# Altium schematic sheet Sheet1.SchDoc
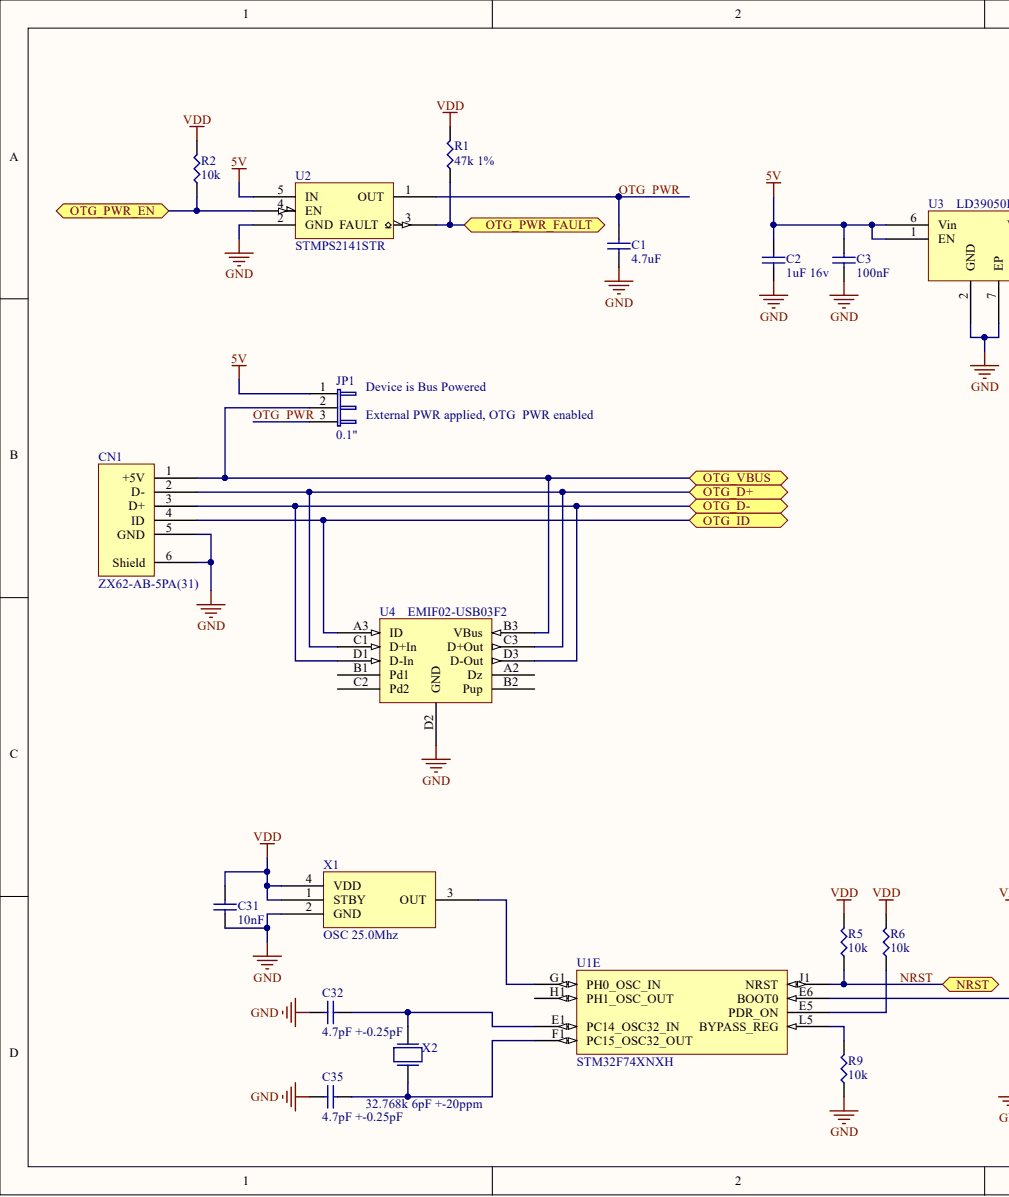
[diagram p0: Sheet1.SchDoc, left]
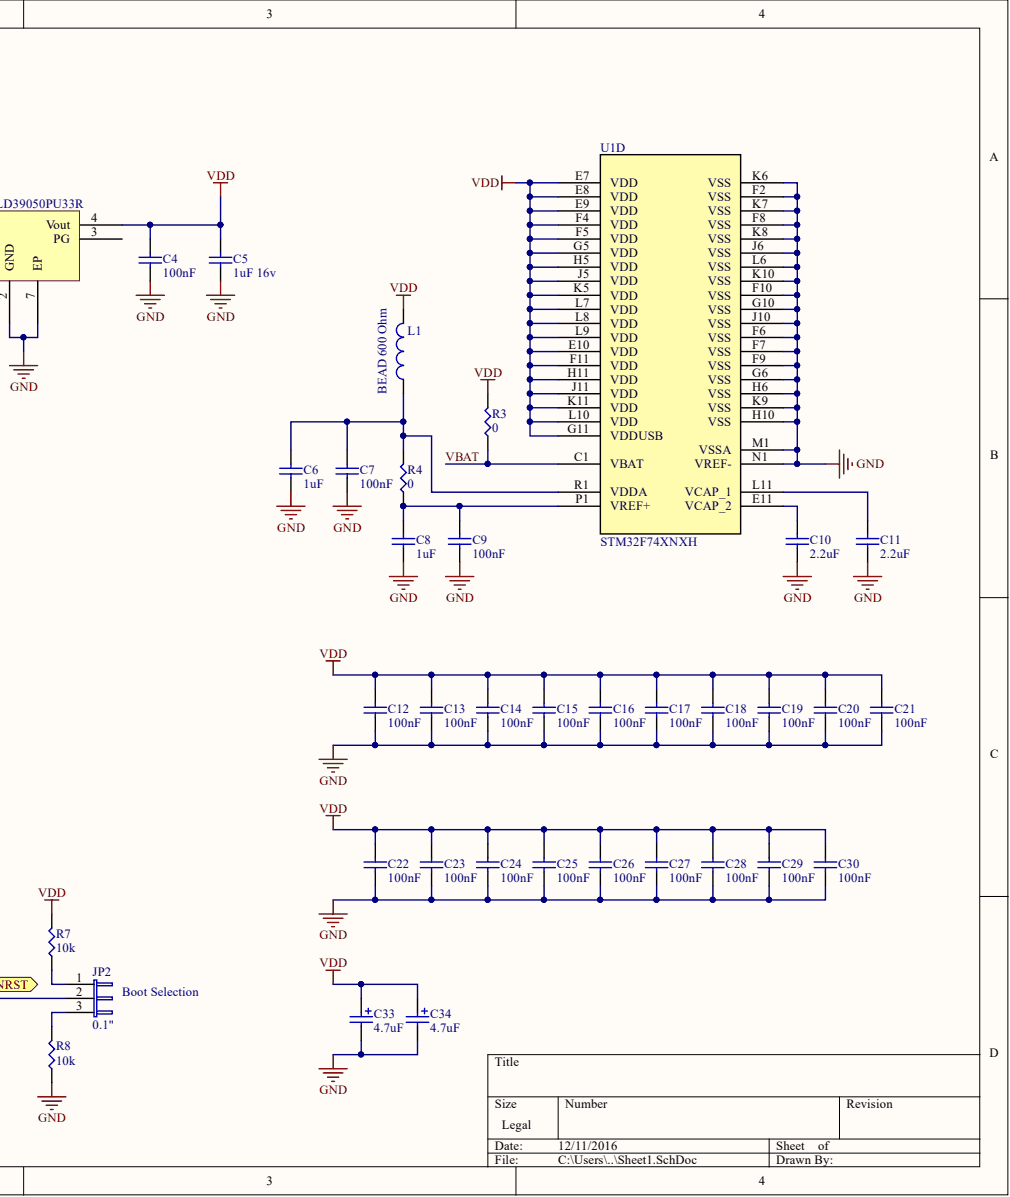
[diagram p1: Sheet1.SchDoc, right]

## Parts
C1  4.7uF  [C0603]
C2  1uF 16v
C3  100nF  [C0402]
C4  100nF  [C0402]
C5  1uF 16v
C6  1uF  [C0402]
C7  100nF  [C0402]
C8  1uF  [C0402]
C9  100nF  [C0402]
C10  2.2uF  [C0402]
C11  2.2uF  [C0402]
C12  100nF  [C0402]
C13  100nF  [C0402]
C14  100nF  [C0402]
C15  100nF  [C0402]
C16  100nF  [C0402]
C17  100nF  [C0402]
C18  100nF  [C0402]
C19  100nF  [C0402]
C20  100nF  [C0402]
C21  100nF  [C0402]
C22  100nF  [C0402]
C23  100nF  [C0402]
C24  100nF  [C0402]
C25  100nF  [C0402]
C26  100nF  [C0402]
C27  100nF  [C0402]
C28  100nF  [C0402]
C29  100nF  [C0402]
C30  100nF  [C0402]
C31  10nF  [C0402]
C32  4.7pF +-0.25pF  Capacitance: 4.7pF  [C0402]
C33  4.7uF  [C0805 Polarized]
C34  4.7uF  [C0805 Polarized]
C35  4.7pF +-0.25pF  Capacitance: 4.7pF  [C0402]
CN1  ZX62-AB-5PA(31)  [ZX62-AB-5PA(31)]
JP1  0.1"  [Jumper 3pin 0.1"]
JP2  0.1"  [Jumper 3pin 0.1"]
L1  BEAD 600 Ohm  [L0603]
R1  47k 1%  Resistance (Ohms): 47k  [R0402]
R2  10k  [R0402]
R3  0  [R0805]
R4  0  [R0805]
R5  10k  [R0402]
R6  10k  [R0402]
R7  10k  [R0402]
R8  10k  [R0402]
R9  10k  [R0402]
U1  STM32F74XNXH  [TFBGA-216_13X13]  (parts D,E)
U2  STMPS2141STR  [SOT95P280X145-5N]
U3  LD39050PU33R  [LD39050]
U4  EMIF02-USB03F2  [EMIF02-USB03F2]
X1  OSC 25.0Mhz  [OSC_3.2x2.5]
X2  32.768k 6pF +-20ppm  Load Capacitance: 6pF  [CRYSTAL_3.20x1.50]

## Ports
NRST, OTG_D+, OTG_D-, OTG_ID, OTG_PWR_EN, OTG_PWR_FAULT, OTG_VBUS

## Nets
5V: C2.2 C3.2 JP1.1 U2.5(IN) U3.1(EN) U3.6(Vin)
GND: C1.1 C2.1 C3.1 C4.1 C5.1 C6.1 C7.1 C8.1 C9.1 C10.1 C11.1 C12.1 C13.1 C14.1 C15.1 C16.1 C17.1 C18.1 C19.1 C20.1 C21.1 C22.1 C23.1 C24.1 C25.1 C26.1 C27.1 C28.1 C29.1 C30.1 C31.1 C32.1 C33.2 C34.2 C35.1 CN1.5(GND) CN1.6(Shield) R8.1 R9.1 U1.F2(VSS) U1.F6(VSS) U1.F7(VSS) U1.F8(VSS) U1.F9(VSS) U1.F10(VSS) U1.G6(VSS) U1.G10(VSS) U1.H6(VSS) U1.H10(VSS) U1.J6(VSS) U1.J10(VSS) U1.K6(VSS) U1.K7(VSS) U1.K8(VSS) U1.K9(VSS) U1.K10(VSS) U1.L6(VSS) U1.M1(VSSA) U1.N1(VREF-) U2.2(GND) U3.2(GND) U3.7(EP) U4.D2(GND) X1.2(GND)
VDD: C4.2 C5.2 C12.2 C13.2 C14.2 C15.2 C16.2 C17.2 C18.2 C19.2 C20.2 C21.2 C22.2 C23.2 C24.2 C25.2 C26.2 C27.2 C28.2 C29.2 C30.2 C31.2 C33.1 C34.1 L1.2 R1.2 R2.2 R3.2 R5.2 R6.2 R7.2 U1.E7(VDD) U1.E8(VDD) U1.E9(VDD) U1.E10(VDD) U1.F4(VDD) U1.F5(VDD) U1.F11(VDD) U1.G5(VDD) U1.G11(VDDUSB) U1.H5(VDD) U1.H11(VDD) U1.J5(VDD) U1.J11(VDD) U1.K5(VDD) U1.K11(VDD) U1.L7(VDD) U1.L8(VDD) U1.L9(VDD) U1.L10(VDD) U3.4(Vout) X1.1(STBY) X1.4(VDD)
NRST: R5.1 U1.J1(NRST) ; port NRST
OTG_ID: CN1.4(ID) U4.A3(ID) ; port OTG_ID
OTG_PWR: C1.2 JP1.3 U2.1(OUT)
OTG_PWR_EN: R2.1 U2.4(EN) ; port OTG_PWR_EN
OTG_PWR_FAULT: R1.1 U2.3(FAULT) ; port OTG_PWR_FAULT
OTG_VBUS: CN1.1(+5V) JP1.2 U4.B3(VBus) ; port OTG_VBUS
VBAT: R3.1 U1.C1(VBAT)
NetC6_2: C6.2 C7.2 L1.1 R4.2 U1.R1(VDDA)
NetC8_2: C8.2 C9.2 R4.1 U1.P1(VREF+)
NetC10_2: C10.2 U1.E11(VCAP_2)
NetC11_2: C11.2 U1.L11(VCAP_1)
NetC32_2: C32.2 U1.E1(PC14_OSC32_IN) X2.1
NetC35_2: C35.2 U1.F1(PC15_OSC32_OUT) X2.2
NetCN1_2: CN1.2(D-) U4.C1(D+In) U4.C3(D+Out)
NetCN1_3: CN1.3(D+) U4.D1(D-In) U4.D3(D-Out)
NetJP2_1: JP2.1 R7.1
NetJP2_2: JP2.2 U1.E6(BOOT0)
NetJP2_3: JP2.3 R8.2
NetR6_1: R6.1 U1.E5(PDR_ON)
NetR9_2: R9.2 U1.L5(BYPASS_REG)
NetU1_G1: U1.G1(PH0_OSC_IN) X1.3(OUT)

Not connected: U1 H1(PH1_OSC_OUT); U3 3(PG); U4 A2(Dz) B1(Pd1) B2(Pup) C2(Pd2)

## Library data of the parts
C1 = CL10A475MP5LNNC: CAP CER 4.7UF 10V X5R 0603; Manufacturer: Samsung Electro-Mechanics America, Inc.; Capacitance: 4.7µF; Tolerance: ±20%; Applications: General Purpose; Category: Capacitors; Component Kind: Standard; ComponentLink1Description: http://www.samsungsem.com/kr/support/library/product-catalog/__icsFiles/afieldfile/2016/09/27/MLCC.pdf; ComponentLink1URL: http://www.samsungsem.com/kr/support/library/product-catalog/__icsFiles/afieldfile/2016/09/27/MLCC.pdf; Features: Low Profile; Height - Seated (Max): -; Lead Spacing: -; Lead Style: -; Library Name: Main.SchLib; Manufacturer Part Number: CL10A475MP5LNNC; Mounting Type: Surface Mount, MLCC; Operating Temperature: -55°C ~ 85°C; Package / Case: 0603 (1608 Metric); Packaging: Cut Tape (CT); Part Status: Active; Pricing 1: 1=0.1, 10=0.074, 25=0.0544, 50=0.0422, 100=0.0349, 250=0.02892, 500=0.0245, 1000=0.02028 (USD); Ratings: -; RoHS: RoHS Compliant; Size / Dimension: 0.063" L x 0.031" W (1.60mm x 0.80mm); Stock 1: 31898; Supplier 1: Digi-Key; Supplier Part Number 1: 1276-6684-1-ND; Temperature Coefficient: X5R; Thickness (Max): 0.022" (0.55mm); Voltage - Rated: 10V
C2 C5 = CC0603ZRY5V7BB105: CAP CER 1UF 16V Y5V 0603; Manufacturer: Yageo; Capacitance: 1µF; Temperature Coefficient: Y5V (F); Tolerance: -20%, +80%; Applications: General Purpose; Category: Capacitors; Component Kind: Standard; ComponentLink1Description: http://www.yageo.com/documents/recent/UPY-GPHC_Y5V_6.3V-to-50V_6.pdf; ComponentLink1URL: http://www.yageo.com/documents/recent/UPY-GPHC_Y5V_6.3V-to-50V_6.pdf; Failure Rate: -; Features: -; Height - Seated (Max): -; Lead Spacing: -; Lead Style: -; Library Name: Main.SchLib; Manufacturer Part Number: CC0603ZRY5V7BB105; Mounting Type: Surface Mount, MLCC; Operating Temperature: -30°C ~ 85°C; Package / Case: 0603 (1608 Metric); Packaging: Cut Tape (CT); Part Status: Active; Pricing 1: 1=0.1, 10=0.033, 25=0.0236, 100=0.0152, 250=0.01248, 500=0.01068, 1000=0.00832 (USD); Ratings: -; RoHS: RoHS Compliant; Size / Dimension: 0.063" L x 0.031" W (1.60mm x 0.80mm); Stock 1: 840235; Supplier 1: Digi-Key; Supplier Part Number 1: 311-1372-1-ND; Thickness (Max): 0.035" (0.90mm); Voltage - Rated: 16V
C3 C4 C7 C9 C12-C30 = GRM155R71C104KA88J: CAP CER 0.1UF 16V X7R 0402; Manufacturer: Murata Electronics North America; Capacitance: 0.10µF; Tolerance: ±10%; Applications: General Purpose; Category: Capacitors; Component Kind: Standard; ComponentLink1Description: http://www.murata.com/~/media/webrenewal/support/library/catalog/products/capacitor/mlcc/c02e.pdf; ComponentLink1URL: http://www.murata.com/~/media/webrenewal/support/library/catalog/products/capacitor/mlcc/c02e.pdf; Features: -; Height - Seated (Max): -; Lead Spacing: -; Library Name: Main.SchLib; Manufacturer Part Number: GRM155R71C104KA88J; Mounting Type: Surface Mount, MLCC; Operating Temperature: -55°C ~ 125°C; Package / Case: 0402 (1005 Metric); Packaging: Cut Tape (CT); Part Status: Active; Pricing 1: 1=0.1, 10=0.012, 50=0.0066, 100=0.0055, 250=0.00452, 500=0.00386, 1000=0.003, 5000=0.0025, 10000=0.0023 (USD); Ratings: -; RoHS: RoHS Compliant; Size / Dimension: 0.039" L x 0.020" W (1.00mm x 0.50mm); Stock 1: 28696221; Supplier 1: Digi-Key; Supplier Part Number 1: 490-6328-1-ND; Temperature Coefficient: X7R; Thickness (Max): 0.022" (0.55mm); Voltage - Rated: 16V
C6 C8 = GRM155R60J105KE19D: CAP CER 1UF 6.3V X5R 0402; Manufacturer: Murata Electronics North America; Capacitance: 1µF; Tolerance: ±10%; Applications: General Purpose; Category: Capacitors; Component Kind: Standard; ComponentLink1Description: http://www.murata.com/~/media/webrenewal/support/library/catalog/products/capacitor/mlcc/c02e.pdf; ComponentLink1URL: http://www.murata.com/~/media/webrenewal/support/library/catalog/products/capacitor/mlcc/c02e.pdf; Features: -; Height - Seated (Max): -; Lead Spacing: -; Lead Style: -; Library Name: Main.SchLib; Manufacturer Part Number: GRM155R60J105KE19D; Mounting Type: Surface Mount, MLCC; Operating Temperature: -55°C ~ 85°C; Package / Case: 0402 (1005 Metric); Packaging: Cut Tape (CT); Part Status: Active; Pricing 1: 1=0.1, 10=0.021, 50=0.0114, 100=0.0096, 250=0.00784, 500=0.0067, 1000=0.00522, 5000=0.00435 (USD); Ratings: -; RoHS: RoHS Compliant; Size / Dimension: 0.039" L x 0.020" W (1.00mm x 0.50mm); Stock 1: 3970482; Supplier 1: Digi-Key; Supplier Part Number 1: 490-1320-1-ND; Temperature Coefficient: X5R; Thickness (Max): 0.022" (0.55mm); Voltage - Rated: 6.3V
C10 C11 = 04026D225KAT2A: CAP CER 2.2UF 6.3V X5R 0402; Manufacturer: AVX Corporation; Capacitance: 2.2µF; Tolerance: ±10%; Applications: General Purpose; Category: Capacitors; Component Kind: Standard; ComponentLink1Description: http://datasheets.avx.com/cx5r.pdf; ComponentLink1URL: http://datasheets.avx.com/cx5r.pdf; Features: -; Height - Seated (Max): -; Lead Spacing: -; Lead Style: -; Library Name: Main.SchLib; Manufacturer Part Number: 04026D225KAT2A; Mounting Type: Surface Mount, MLCC; Operating Temperature: -55°C ~ 85°C; Package / Case: 0402 (1005 Metric); Packaging: Cut Tape (CT); Part Status: Active; Pricing 1: 1=0.1, 10=0.075, 25=0.0544, 50=0.0424, 100=0.0351, 250=0.029, 500=0.02458, 1000=0.02035, 5000=0.01733 (USD); Ratings: -; RoHS: RoHS Compliant; Size / Dimension: 0.039" L x 0.020" W (1.00mm x 0.50mm); Stock 1: 639807; Supplier 1: Digi-Key; Supplier Part Number 1: 478-7885-1-ND; Temperature Coefficient: X5R; Thickness (Max): 0.022" (0.56mm); Voltage - Rated: 6.3V
C31 = GRM155R71H103KA88D: CAP CER 10000PF 50V X7R 0402; Manufacturer: Murata Electronics North America; Tolerance: ±10%; Applications: General Purpose; Capacitance: 10000pF; Category: Capacitors; Component Kind: Standard; ComponentLink1Description: http://www.murata.com/~/media/webrenewal/support/library/catalog/products/capacitor/mlcc/c02e.pdf; ComponentLink1URL: http://www.murata.com/~/media/webrenewal/support/library/catalog/products/capacitor/mlcc/c02e.pdf; Features: -; Height - Seated (Max): -; Lead Spacing: -; Lead Style: -; Library Name: Main.SchLib; Manufacturer Part Number: GRM155R71H103KA88D; Mounting Type: Surface Mount, MLCC; Operating Temperature: -55°C ~ 125°C; Package / Case: 0402 (1005 Metric); Packaging: Cut Tape (CT); Part Status: Active; Pricing 1: 1=0.1, 50=0.0052, 100=0.0044, 250=0.0036, 500=0.00308, 1000=0.0024, 5000=0.002 (USD); Ratings: -; RoHS: RoHS Compliant; Size / Dimension: 0.039" L x 0.020" W (1.00mm x 0.50mm); Stock 1: 4828857; Supplier 1: Digi-Key; Supplier Part Number 1: 490-4516-1-ND; Temperature Coefficient: X7R; Thickness (Max): 0.022" (0.55mm); Voltage - Rated: 50V
C32 C35 = CL05C4R7CB5NNNC: CAP CER 4.7PF 50V NP0 0402; Manufacturer: Samsung Electro-Mechanics America, Inc.; Temperature Coefficient: C0G, NP0; Tolerance: ±0.25pF; Applications: General Purpose; Category: Capacitors; Component Kind: Standard; ComponentLink1Description: http://www.samsungsem.com/kr/support/product-search/mlcc/__icsFiles/afieldfile/2016/08/18/S_CL05C4R7CB5NNNC.pdf; ComponentLink1URL: http://www.samsungsem.com/kr/support/product-search/mlcc/__icsFiles/afieldfile/2016/08/18/S_CL05C4R7CB5NNNC.pdf; Features: -; Height - Seated (Max): -; Lead Spacing: -; Lead Style: -; Library Name: Main.SchLib; Manufacturer Part Number: CL05C4R7CB5NNNC; Mounting Type: Surface Mount, MLCC; Operating Temperature: -55°C ~ 125°C; Package / Case: 0402 (1005 Metric); Packaging: Cut Tape (CT); Part Status: Active; Pricing 1: 1=0.1, 10=0.015, 25=0.0112, 50=0.0084, 100=0.0069, 250=0.00576, 500=0.0049, 1000=0.00385, 5000=0.00322 (USD); Ratings: -; RoHS: RoHS Compliant; Size / Dimension: 0.039" L x 0.020" W (1.00mm x 0.50mm); Stock 1: 159620; Supplier 1: Digi-Key; Supplier Part Number 1: 1276-1703-1-ND; Thickness (Max): 0.022" (0.55mm); Voltage - Rated: 50V
C33 C34 = F921A475MPA: CAP TANT 4.7UF 10V 20% 0805; Manufacturer: AVX Corporation; Manufacturer Size Code: P; Capacitance: 4.7µF; ESR (Equivalent Series Resistance): 6 Ohm; Type: Molded; Category: Capacitors; Component Kind: Standard; ComponentLink1Description: http://datasheets.avx.com/f92.pdf; ComponentLink1URL: http://datasheets.avx.com/f92.pdf; Failure Rate: -; Features: General Purpose; Height - Seated (Max): 0.039" (1.00mm); Lead Spacing: -; Library Name: Main.SchLib; Lifetime @ Temp.: -; Manufacturer Part Number: F921A475MPA; Mounting Type: Surface Mount; Operating Temperature: -55°C ~ 125°C; Package / Case: 0805 (2012 Metric); Packaging: Cut Tape (CT); Part Status: Active; Pricing 1: 1=0.29, 10=0.204, 25=0.1672, 50=0.1426, 100=0.1223, 250=0.10192, 500=0.09174, 1000=0.07746 (USD); RoHS: RoHS Compliant; Size / Dimension: 0.079" L x 0.049" W (2.00mm x 1.25mm); Stock 1: 10365; Supplier 1: Digi-Key; Supplier Part Number 1: 478-8125-1-ND; Tolerance: ±20%; Voltage - Rated: 10V
CN1 = ZX62-AB-5PA(31): CONN RCPT USB MICRO AB SMD R/A; Manufacturer: Hirose Electric Co Ltd; Connector Type: USB - micro AB; Current Rating: 1A per Contact; Gender: Receptacle; Material Flammability Rating: UL94 V-0; Mating Cycles: 10000; Number of Contacts: 5; Number of Ports: 1; Shielding: Shielded; Specifications: USB 2.0; Voltage - Rated: 30VAC; Category: Connectors, Interconnects; Component Kind: Standard; ComponentLink1Description: https://www.hirose.com/product/download/?distributor=digikey&type=2d&lang=en&num=ZX62-AB-5PA(31); ComponentLink1URL: https://www.hirose.com/product/download/?distributor=digikey&type=2d&lang=en&num=ZX62-AB-5PA(31); Contact Finish: Gold; Features: Pick and Place, Solder Retention; Ingress Protection: -; Insulation Color: Gray; Library Name: Main.SchLib; Manufacturer Part Number: ZX62-AB-5PA(31); Mounting Feature: Horizontal; Mounting Type: Surface Mount, Right Angle; Operating Temperature: -30°C ~ 85°C; Packaging: Cut Tape (CT); Part Status: Active; Pricing 1: 1=0.83, 10=0.729, 25=0.6864, 50=0.6578, 100=0.6292, 250=0.572, 500=0.5148, 1000=0.4576 (USD); RoHS: RoHS Compliant; Shell/Shielding Material: Steel, Stainless; Stock 1: 14730; Supplier 1: Digi-Key; Supplier Part Number 1: H125279CT-ND; Termination: Solder
JP1 JP2 = Jumper 3Pin 0.1": Component Kind: Standard; Library Name: Main.SchLib
L1 = BK1608HW601-T: FERRITE BEAD 600 OHM 0603 1LN; Manufacturer: Taiyo Yuden; Current Rating (Max): 300mA; DC Resistance (DCR) (Max): 400 mOhm; Impedance @ Frequency: 600 Ohm @ 100MHz; Number of Lines: 1; Category: Filters; Component Kind: Standard; ComponentLink1Description: http://ds.yuden.co.jp/TYCOMPAS/ut/detail.do?productNo=BK1608HW601-T&fileName=BK1608HW601-T_SS&mode=specSheetDownload; ComponentLink1URL: http://ds.yuden.co.jp/TYCOMPAS/ut/detail.do?productNo=BK1608HW601-T&fileName=BK1608HW601-T_SS&mode=specSheetDownload; Filter Type: -; Height (Max): 0.037" (0.95mm); Library Name: Main.SchLib; Manufacturer Part Number: BK1608HW601-T; Mounting Type: Surface Mount; Operating Temperature: -55°C ~ 125°C; Package / Case: 0603 (1608 Metric); Packaging: Cut Tape (CT); Part Status: Active; Pricing 1: 1=0.1, 10=0.059, 50=0.0474, 100=0.0426, 250=0.0312, 500=0.0227, 1000=0.02223 (USD); Ratings: -; RoHS: RoHS Compliant; Size / Dimension: 0.063" L x 0.031" W (1.60mm x 0.80mm); Stock 1: 46168; Supplier 1: Digi-Key; Supplier Part Number 1: 587-1879-1-ND
R1 = ERJ-2RKF4702X: RES SMD 47K OHM 1% 1/10W 0402; Manufacturer: Panasonic Electronic Components; Power (Watts): 0.1W, 1/10W; Temperature Coefficient: ±100ppm/°C; Category: Resistors; Component Kind: Standard; ComponentLink1Description: http://industrial.panasonic.com/www-cgi/jvcr13pz.cgi?E+PZ+3+AOA0002+ERJ2RKF4702X+7+WW; ComponentLink1URL: http://industrial.panasonic.com/www-cgi/jvcr13pz.cgi?E+PZ+3+AOA0002+ERJ2RKF4702X+7+WW; Composition: Thick Film; Failure Rate: -; Features: Automotive AEC-Q200; Height - Seated (Max): 0.016" (0.40mm); Library Name: Main.SchLib; Manufacturer Part Number: ERJ-2RKF4702X; Number of Terminations: 2; Operating Temperature: -55°C ~ 155°C; Package / Case: 0402 (1005 Metric); Packaging: Cut Tape (CT); Part Status: Active; Pricing 1: 1=0.1, 50=0.015, 100=0.011, 250=0.0084, 500=0.00674, 1000=0.00496, 5000=0.00355 (USD); RoHS: RoHS Compliant; Size / Dimension: 0.039" L x 0.020" W (1.00mm x 0.50mm); Stock 1: 296471; Supplier 1: Digi-Key; Supplier Device Package: 0402; Supplier Part Number 1: P47.0KLCT-ND; Tolerance: ±1%
R2 R5-R9 = RC0402FR-0710KL: RES SMD 10K OHM 1% 1/16W 0402; Manufacturer: Yageo; Power (Watts): 0.063W, 1/16W; Temperature Coefficient: ±100ppm/°C; Category: Resistors; Component Kind: Standard; ComponentLink1Description: http://www.yageo.com/NewPortal/yageodocoutput?fileName=/pdf/R-Chip/PYu-RC_Group_51_RoHS_L_4.pdf; ComponentLink1URL: http://www.yageo.com/NewPortal/yageodocoutput?fileName=/pdf/R-Chip/PYu-RC_Group_51_RoHS_L_4.pdf; Composition: Thick Film; Failure Rate: -; Features: Moisture Resistant; Height - Seated (Max): 0.016" (0.40mm); Library Name: Main.SchLib; Manufacturer Part Number: RC0402FR-0710KL; Number of Terminations: 2; Operating Temperature: -55°C ~ 155°C; Package / Case: 0402 (1005 Metric); Packaging: Cut Tape (CT); Part Status: Active; Pricing 1: 1=0.1, 10=0.013, 25=0.0096, 100=0.0054, 250=0.00412, 500=0.00328, 1000=0.00242, 2500=0.0021, 5000=0.00173 (USD); Resistance (Ohms): 10k; RoHS: RoHS Compliant; Size / Dimension: 0.039" L x 0.020" W (1.00mm x 0.50mm); Stock 1: 13115833; Supplier 1: Digi-Key; Supplier Device Package: 0402; Supplier Part Number 1: 311-10.0KLRCT-ND; Tolerance: ±1%
R3 R4 = RC0805JR-070RL: RES SMD 0.0 OHM JUMPER 1/8W 0805; Manufacturer: Yageo; Power (Watts): 0.125W, 1/8W; Resistance (Ohms): 0.0; Category: Resistors; Component Kind: Standard; ComponentLink1Description: http://www.yageo.com/NewPortal/yageodocoutput?fileName=/pdf/R-Chip/PYu-RC_Group_51_RoHS_L_4.pdf; ComponentLink1URL: http://www.yageo.com/NewPortal/yageodocoutput?fileName=/pdf/R-Chip/PYu-RC_Group_51_RoHS_L_4.pdf; Composition: Thick Film; Failure Rate: -; Features: Moisture Resistant; Height - Seated (Max): 0.024" (0.60mm); Library Name: Main.SchLib; Manufacturer Part Number: RC0805JR-070RL; Number of Terminations: 2; Operating Temperature: -55°C ~ 155°C; Package / Case: 0805 (2012 Metric); Packaging: Cut Tape (CT); Part Status: Active; Pricing 1: 1=0.1, 10=0.018, 25=0.0128, 100=0.0073, 250=0.00556, 500=0.00444, 1000=0.00326, 2500=0.00283 (USD); RoHS: RoHS Compliant; Size / Dimension: 0.079" L x 0.049" W (2.00mm x 1.25mm); Stock 1: 2486712; Supplier 1: Digi-Key; Supplier Device Package: 0805; Supplier Part Number 1: 311-0.0ARCT-ND; Temperature Coefficient: -; Tolerance: Jumper
U1 = STM32F74XNXH: Imported; Manufacturer: STMicroelectronics; Manufacturer Part Number: STM32F746NGH6; C: Copyright (C) 2016 Accelerated Designs. All rights reserved; Connectivity: CAN, EBI/EMI, Ethernet, I²C, IrDA, LIN, SAI, SD, SPDIF-Rx, SPI, UART/USART, USB OTG; Core Processor: ARM® Cortex®-M7; Core Size: 32-Bit; Data Converters: A/D 24x12b, D/A 2x12b; Number of I/O: 168; Oscillator Type: Internal; Peripherals: Brown-out Detect/Reset, DMA, I²S, LCD, POR, PWM, WDT; Program Memory Size: 1MB (1M x 8); Program Memory Type: FLASH; RAM Size: 320K x 8; Speed: 216MHz; Voltage - Supply (Vcc/Vdd): 1.7 V ~ 3.6 V; Category: Integrated Circuits (ICs); Component Kind: Standard; ComponentLink1Description: http://www.st.com/content/ccc/resource/technical/document/datasheet/96/ed/61/9b/e0/6c/45/0b/DM00166116.pdf/files/DM00166116.pdf/jcr:content/translations/en.DM00166116.pdf; ComponentLink1URL: http://www.st.com/content/ccc/resource/technical/document/datasheet/96/ed/61/9b/e0/6c/45/0b/DM00166116.pdf/files/DM00166116.pdf/jcr:content/translations/en.DM00166116.pdf; EEPROM Size: -; Library Name: Main.SchLib; Manufacturer_Part_Number: stm32f74xnxh; Operating Temperature: -40°C ~ 85°C (TA); Package / Case: 216-TFBGA; Packaging: Tray; Part Status: Active; Pricing 1: 1=17.07, 10=15.687, 100=13.2486, 500=11.7856 (USD); RefDes: RefDes; RoHS: RoHS Compliant; Stock 1: 825; Supplier 1: Digi-Key; Supplier Device Package: 216-TFBGA (13x13); Supplier Part Number 1: 497-15818-ND; Type: Type; Value: Value; Vendor: STMicroelectronics; Copyright: Copyright (C) 2016 Accelerated Designs. All rights reserved
U2 = STMPS2141STR: IC PWR SWITCH 1CH 500MA SOT23-5; Manufacturer: STMicroelectronics; Fault Protection: Current Limiting (Fixed), Over Temperature, Reverse Current, UVLO; Input Type: Non-Inverting; Interface: On/Off; Number of Outputs: 1; Output Configuration: High Side; Output Type: N-Channel; Ratio - Input: 1:1; Rds On (Typ): 90 mOhm; Switch Type: General Purpose; Voltage - Load: 2.7 V ~ 5.5 V; Voltage - Supply (Vcc/Vdd): Not Required; Category: Integrated Circuits (ICs); Component Kind: Standard; ComponentLink1Description: http://www.st.com/content/ccc/resource/technical/document/datasheet/e8/d7/48/25/eb/87/45/b3/CD00167470.pdf/files/CD00167470.pdf/jcr:content/translations/en.CD00167470.pdf; ComponentLink1URL: http://www.st.com/content/ccc/resource/technical/document/datasheet/e8/d7/48/25/eb/87/45/b3/CD00167470.pdf/files/CD00167470.pdf/jcr:content/translations/en.CD00167470.pdf; Current - Output (Max): 500mA; Features: -; Library Name: Main.SchLib; Manufacturer Part Number: STMPS2141STR; Operating Temperature: -40°C ~ 125°C (TJ); Package / Case: SC-74A, SOT-753; Packaging: Cut Tape (CT); Part Status: Active; Pricing 1: 1=0.61, 10=0.521, 100=0.3893, 500=0.30592, 1000=0.23639 (USD); RoHS: RoHS Compliant; Stock 1: 9855; Supplier 1: Digi-Key; Supplier Device Package: SOT-23-5; Supplier Part Number 1: 497-6933-1-ND
U3 = LD39050PU33R: IC REG LDO 3.3V 0.5A 6DFN; Manufacturer: STMicroelectronics; Current - Output: 500mA; Number of Regulators: 1; Regulator Topology: Positive Fixed; Voltage - Dropout (Typical): 0.2V @ 500mA; Voltage - Input: Up to 5.5V; Category: Integrated Circuits (ICs); Component Kind: Standard; ComponentLink1Description: http://www.st.com/content/ccc/resource/technical/document/datasheet/12/43/cc/93/21/f6/41/66/CD00227527.pdf/files/CD00227527.pdf/jcr:content/translations/en.CD00227527.pdf; ComponentLink1URL: http://www.st.com/content/ccc/resource/technical/document/datasheet/12/43/cc/93/21/f6/41/66/CD00227527.pdf/files/CD00227527.pdf/jcr:content/translations/en.CD00227527.pdf; Current - Limit (Min): -; Library Name: Main.SchLib; Manufacturer Part Number: LD39050PU33R; Mounting Type: Surface Mount; Operating Temperature: -40°C ~ 125°C; Package / Case: 6-VDFN Exposed Pad; Packaging: Cut Tape (CT); Part Status: Active; Pricing 1: 1=1.07, 10=0.957, 100=0.7462, 500=0.61646, 1000=0.48668 (USD); RoHS: RoHS Compliant; Stock 1: 4096; Supplier 1: Digi-Key; Supplier Device Package: 6-DFN (3x3); Supplier Part Number 1: 497-8716-1-ND; Voltage - Output: 3.3V
U4 = EMIF02-USB03F2: FILTER RC(PI) 33 OHM/20PF SMD; Manufacturer: STMicroelectronics; ESD Protection: Yes; Filter Order: 2nd; Number of Channels: 2; Resistance - Channel (Ohms): 33; Values: R = 33 Ohms, C = 20pF; Applications: USB; Attenuation Value: -; Category: Filters; Center / Cutoff Frequency: -; Component Kind: Standard; ComponentLink1Description: http://www.st.com/content/ccc/resource/technical/document/datasheet/0a/25/37/a6/28/ab/4f/24/CD00042880.pdf/files/CD00042880.pdf/jcr:content/translations/en.CD00042880.pdf; ComponentLink1URL: http://www.st.com/content/ccc/resource/technical/document/datasheet/0a/25/37/a6/28/ab/4f/24/CD00042880.pdf/files/CD00042880.pdf/jcr:content/translations/en.CD00042880.pdf; Current: -; Height: 0.028" (0.70mm); Library Name: Main.SchLib; Manufacturer Part Number: EMIF02-USB03F2; Mounting Type: Surface Mount; Operating Temperature: -40°C ~ 85°C; Package / Case: 11-WFBGA, FCBGA; Packaging: Cut Tape (CT); Part Status: Active; Pricing 1: 1=0.68, 10=0.644, 100=0.4574, 500=0.39502, 1000=0.35343 (USD); RoHS: RoHS Compliant; Size / Dimension: 0.076" L x 0.057" W (1.94mm x 1.44mm); Stock 1: 8918; Supplier 1: Digi-Key; Supplier Part Number 1: 497-13521-1-ND; Technology: RC (Pi); Type: Low Pass; Voltage - Rated: -
X1 = ASEMB-25.000MHZ-LC-T: OSC MEMS 25.000MHZ CMOS SMD; Manufacturer: Abracon LLC; Current - Supply (Disable) (Max): 15µA; Current - Supply (Max): 16mA; Frequency: 25MHz; Frequency Stability: ±50ppm; Function: Enable/Disable; Voltage - Supply: 1.8 V ~ 3.3 V; Category: Crystals, Oscillators, Resonators; Component Kind: Standard; ComponentLink1Description: http://www.abracon.com/Oscillators/ASEMB.pdf; ComponentLink1URL: http://www.abracon.com/Oscillators/ASEMB.pdf; Height - Seated (Max): 0.035" (0.90mm); Library Name: Main.SchLib; Manufacturer Part Number: ASEMB-25.000MHZ-LC-T; Mounting Type: Surface Mount; Operating Temperature: -40°C ~ 85°C; Output: CMOS; Package / Case: 4-SMD, No Lead (DFN, LCC); Packaging: Cut Tape (CT); Part Status: Active; Pricing 1: 1=1.14, 10=1.088, 50=1.0626, 100=0.9108, 500=0.8855 (USD); Ratings: -; RoHS: RoHS Compliant; Size / Dimension: 0.126" L x 0.098" W (3.20mm x 2.50mm); Stock 1: 27584; Supplier 1: Digi-Key; Supplier Part Number 1: 535-11750-1-ND; Type: MEMS (Silicon)
X2 = NX3215SA-32.768K-STD-MUA-14: CRYSTAL 32.7680KHZ 6PF SMD; Manufacturer: NDK; ESR (Equivalent Series Resistance): 70 kOhm; Frequency: 32.768kHz; Frequency Tolerance: ±20ppm; Operating Mode: Fundamental; Category: Crystals, Oscillators, Resonators; Component Kind: Standard; ComponentLink1Description: http://www.ndk.com/images/products/catalog/c_NX3215SA-STD-MUA-14_e.pdf; ComponentLink1URL: http://www.ndk.com/images/products/catalog/c_NX3215SA-STD-MUA-14_e.pdf; Frequency Stability: -; Height - Seated (Max): 0.035" (0.90mm); Library Name: Main.SchLib; Manufacturer Part Number: NX3215SA-32.768K-STD-MUA-14; Mounting Type: Surface Mount; Operating Temperature: -40°C ~ 85°C; Package / Case: 2-SMD; Packaging: Cut Tape (CT); Part Status: Active; Pricing 1: 1=0.94, 10=0.835, 50=0.7804, 100=0.6897, 500=0.6534, 1000=0.5445 (USD); Ratings: -; RoHS: RoHS Compliant; Size / Dimension: 0.126" L x 0.059" W (3.20mm x 1.50mm); Stock 1: 5426; Supplier 1: Digi-Key; Supplier Part Number 1: 644-1171-1-ND; Type: kHz Crystal (Tuning Fork)
